# Altium schematic sheet Lights.SchDoc
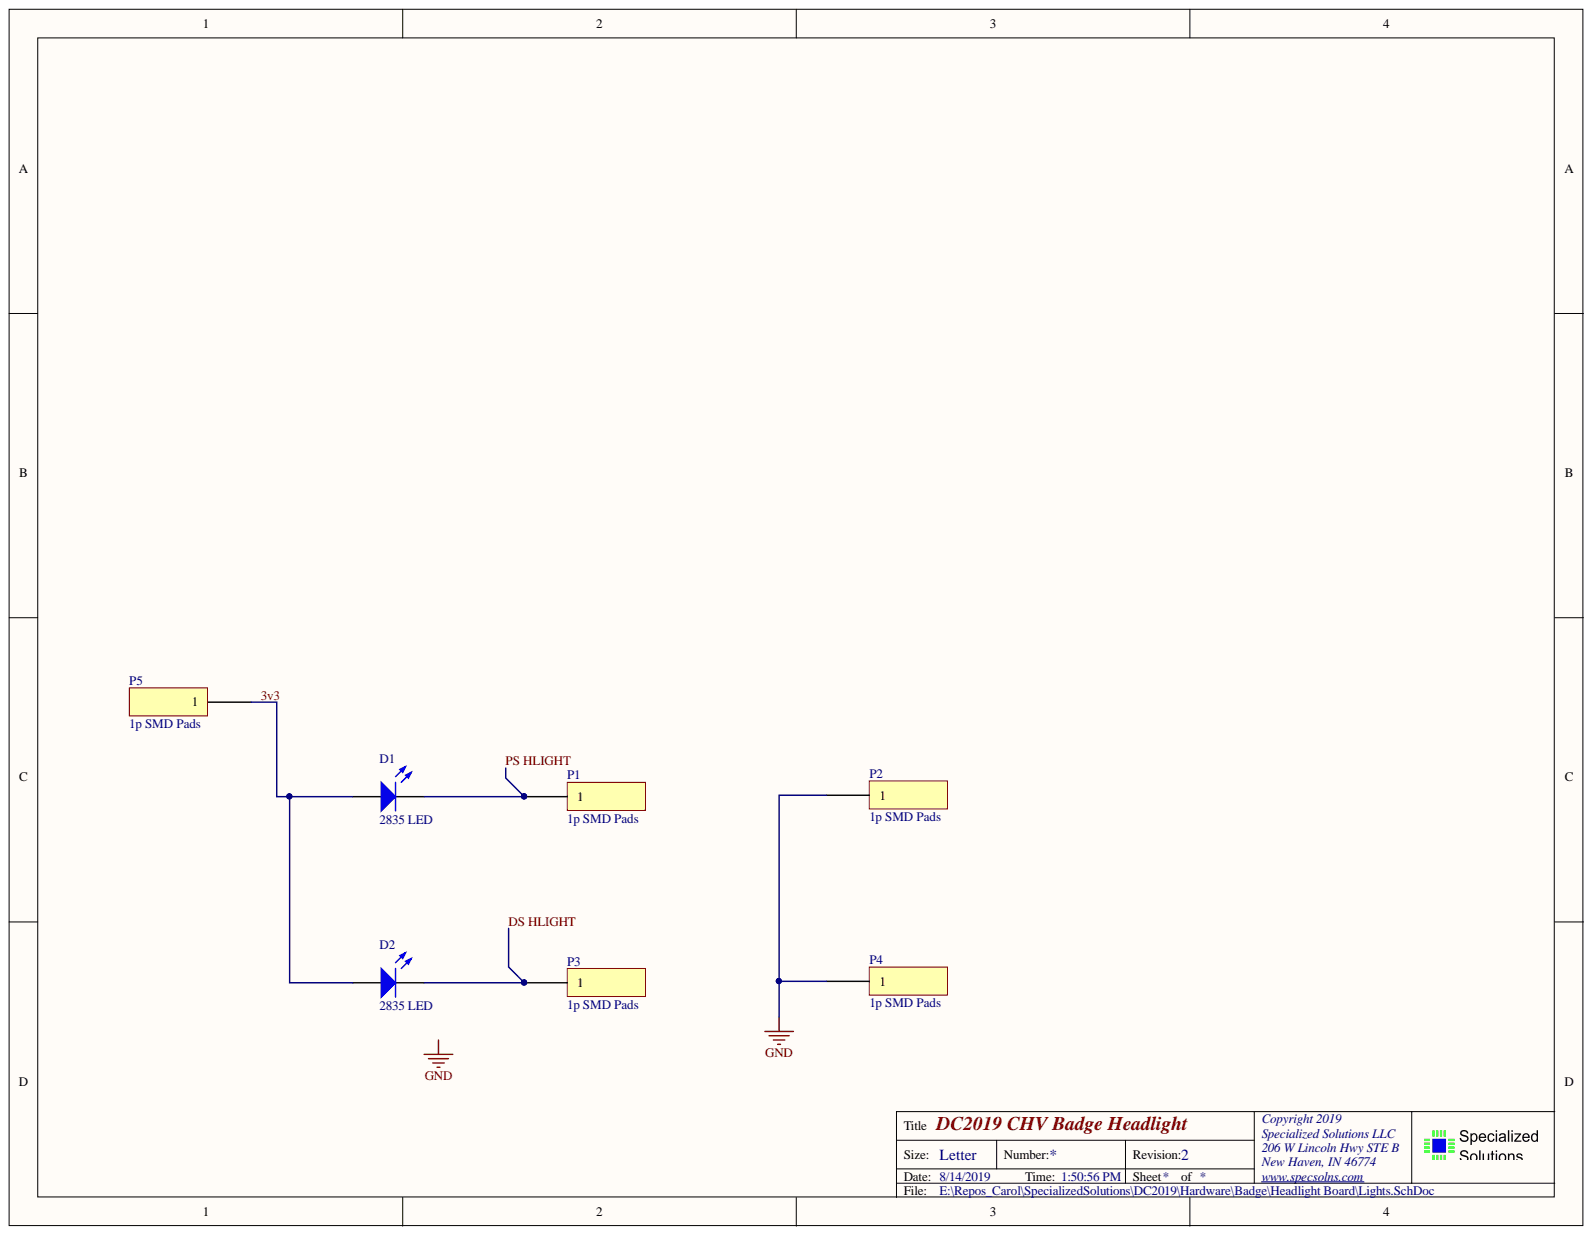
[diagram p0: Lights.SchDoc]

## Parts
D1  2835 LED  [2835 LED]
D2  2835 LED  [2835 LED]
P1  1p SMD Pads  [1p SMD pad]
P2  1p SMD Pads  [1p SMD pad]
P3  1p SMD Pads  [1p SMD pad]
P4  1p SMD Pads  [1p SMD pad]
P5  1p SMD Pads  [1p SMD pad]

## Nets
GND: P2.1 P4.1
3v3: D1.1 D2.1 P5.1
DS HLIGHT: D2.2 P3.1
PS HLIGHT: D1.2 P1.1

## Library data of the parts
D1 D2 = 2835 LED: White LED Songxin Light; Component Kind: Standard; Library Name: parts (fraker's conflicted copy 2018-10-08).schlib
P1-P5 = 1p SMD: Component Kind: Standard; Library Name: parts.schlib
